# Altium schematic sheet FLASH.SchDoc
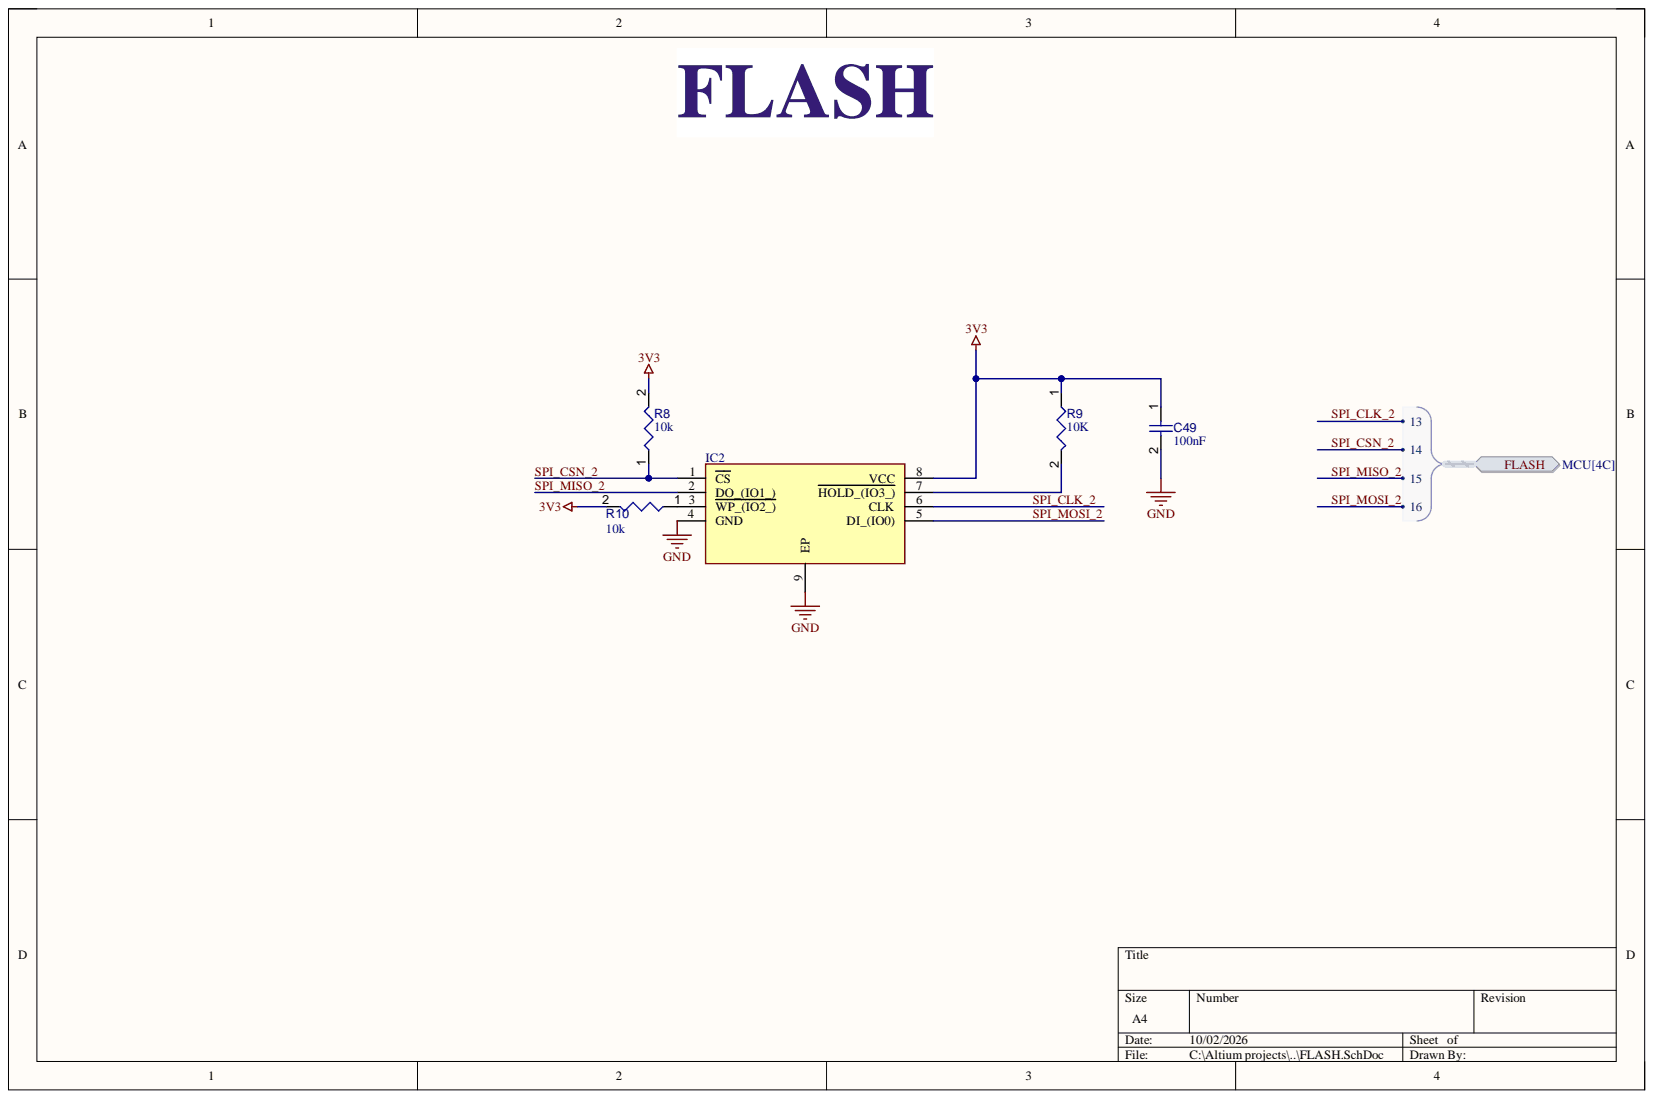
[diagram p0: FLASH.SchDoc]

## Parts
C49  value 100nF  [CAPC2013X95X50LL10T25]  (hidden comment: Capacitor 100 nF +/-10% 50 V 0805)
IC2  W25N04KVZEIR_TR  [SON127P800X600X80-9N-D]  (value not drawn)
R8  value 10k  [RESC2013X50X35ML15T15]  (hidden comment: Resistor 10k +/-1% 0805 125 mW)
R9  value 10K  [RESC2013X50X35ML15T15]  (hidden comment: Resistor 10k +/-1% 0805 125 mW)
R10  value 10k  [RESC2013X50X35ML15T15]  (hidden comment: Resistor 10k +/-1% 0805 125 mW)

## Ports
FLASH, FLASH.13, FLASH.14, FLASH.15, FLASH.16

## Nets
3V3: C49.1 IC2.8(VCC) R8.2 R9.1 R10.2
GND: C49.2 IC2.4(GND) IC2.9(EP)
SPI_CLK_2: IC2.6(CLK) ; port FLASH
SPI_CSN_2: IC2.1(CS) R8.1 ; port FLASH
SPI_MISO_2: IC2.2(DO_(IO1_)) ; port FLASH
SPI_MOSI_2: IC2.5(DI_(IO0)) ; port FLASH
NetIC2_3: IC2.3(WP_(IO2_)) R10.1
NetIC2_7: IC2.7(HOLD_(IO3_)) R9.2

## Library data of the parts
C49 = CMP-001-00018-6: Chip Capacitor, 100 nF, +/- 10%, 50 V, 0805 (2012 Metric); Capacitor Type: Ceramic; Voltage Rating: 50V; Case/Package: 0805; Component Kind: Standard; Max Operating Temperature: 125°C; Min Operating Temperature: -55°C; Mounting Technology: SM; Pins: 2; RoHS Compliant: True; Tolerance: 10%; Library Name: Kopithan Manoranjithan
IC2 = W25N04KVZEIR_TR: Integrated Circuit; Manufacturer_Name: Winbond; Manufacturer_Part_Number: W25N04KVZEIR TR; Arrow Part Number: W25N04KVZEIR TR; Arrow Price/Stock: https://www.arrow.com/en/products/w25n04kvzeir-tr/winbond-electronics?utm_currency=USD&region=nac; Component Kind: Standard; Datasheet Link: https://www.winbond.com/resource-files/W25N04KVxxIRU_Datasheet_20220207_RevC.pdf; Height: 0.8mm; Mouser Part Number: 454-W25N04KVZEIRTR; Mouser Price/Stock: https://www.mouser.co.uk/ProductDetail/Winbond/W25N04KVZEIR-TR?qs=YwPsRIUVAOfYrPACPCMGfg%3D%3D
R8-R10 = CMP-009-00018-5: Chip Resistor, 10 KOhm, +/- 1%, 125 mW, -55 to 155 degC, 0805 (2012 Metric); Power: 125mW; Voltage Rating: 150V; Case/Package: 0805; Component Kind: Standard; Max Operating Temperature: 155°C; Min Operating Temperature: -55°C; Mounting Technology: SM; Pins: 2; RoHS Compliant: True; Tolerance: 1%; Library Name: Kopithan Manoranjithan
